# Altium schematic sheet Sch.SchDoc
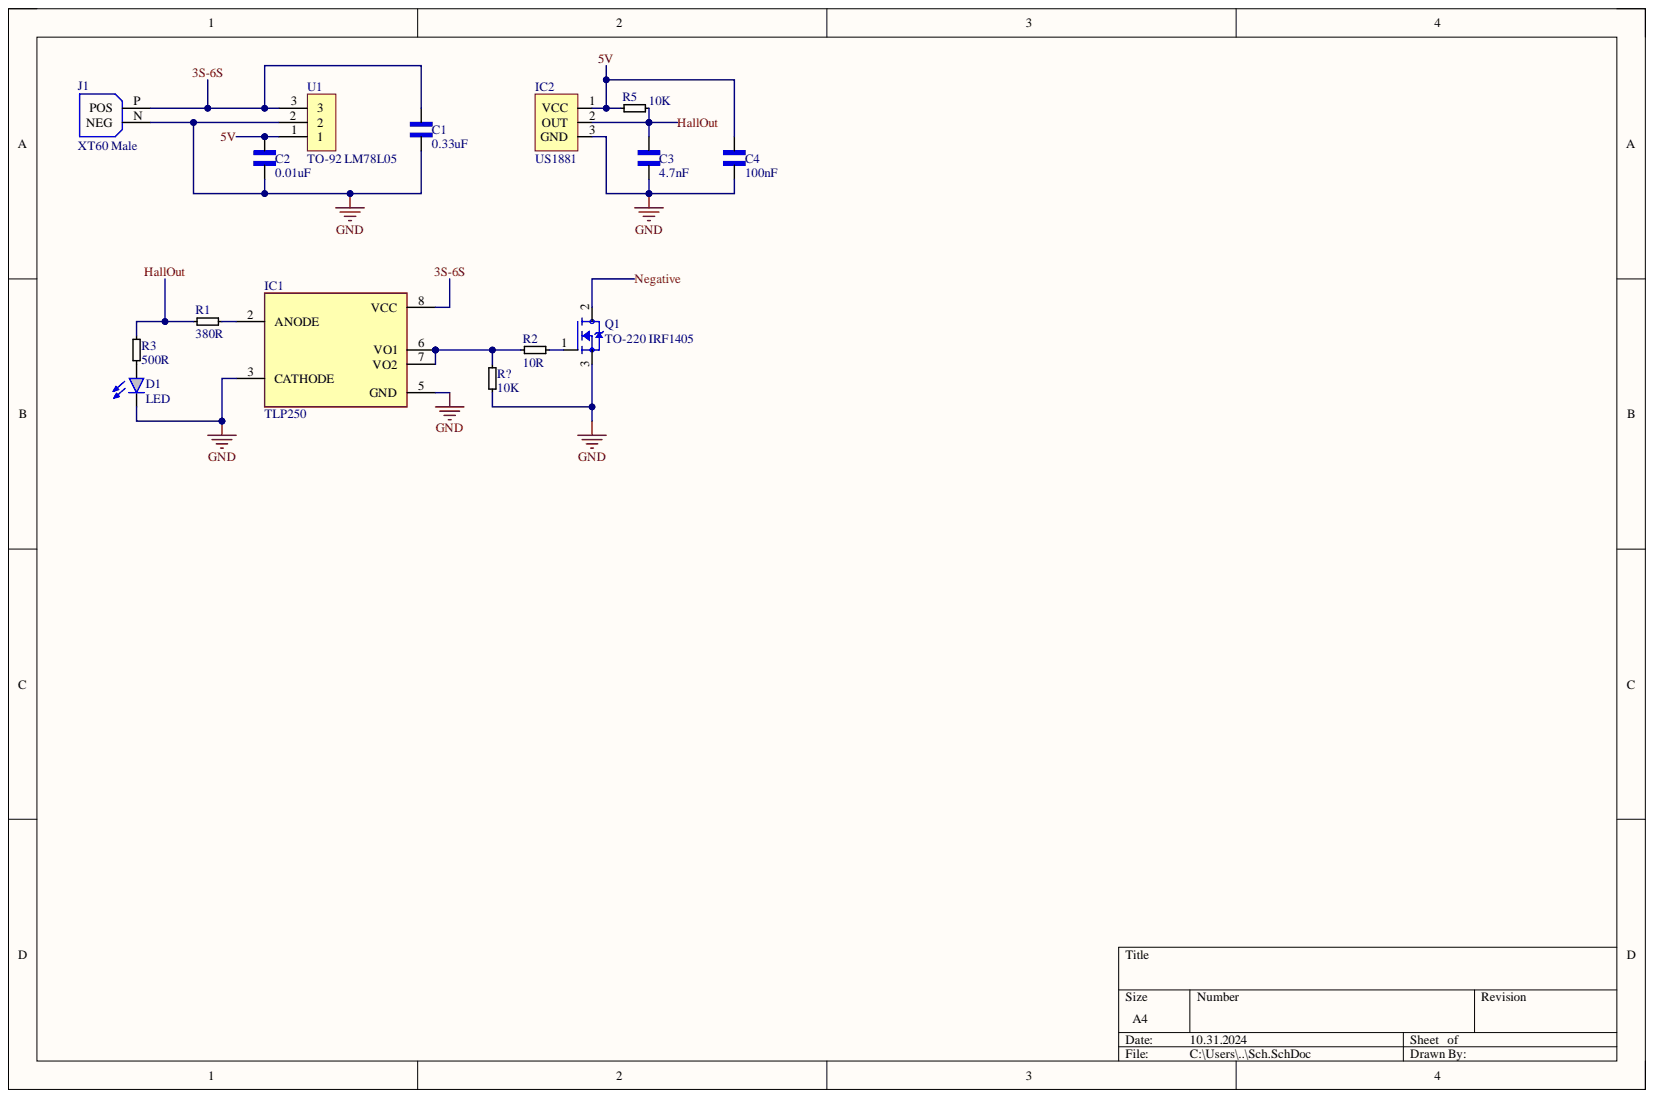
[diagram p0: Sch.SchDoc]

## Parts
C1  0.33uF  [CER-DIP-CAP]
C2  0.01uF  [CER-DIP-CAP]
C3  4.7nF  [CER-DIP-CAP]
C4  100nF  [CER-DIP-CAP]
D1  LED  [LED DIP]
IC1  TLP250  [DIP-8]
IC2  US1881  [UA]
J1  XT60 Male  [XT60 Male Pin]
Q1  TO-220 IRF1405  [TO-220]
R1  380R  [RES DIP]
R2  10R  [RES DIP]
R3  500R  [RES DIP]
R5  10K  [RES DIP]
R?  10K  [RES DIP]
U1  TO-92 LM78L05  [TO-226 TO-92]

## Nets
GND: C1.1 C2.1 C3.1 C4.1 D1.C IC1.3(CATHODE) IC1.5(GND) IC2.3 J1.N Q1.3 R?.1 U1.2
3S-6S: C1.2 IC1.8(VCC) J1.P U1.3
5V: C2.2 C4.2 IC2.1 R5.1 U1.1
HallOut: C3.2 IC2.2 R1.1 R3.1 R5.2
Negative: Q1.2
NetD1_A: D1.A R3.2
NetQ1_1: Q1.1 R2.2
NetR1_2: IC1.2(ANODE) R1.2
NetR2_1: IC1.6(VO1) IC1.7(VO2) R2.1 R?.2

## Library data of the parts
C1-C4 = CAP DIP: Component Kind: Standard; Library Name: MYS-Library.IntLib
D1 = LED DIP: Component Kind: Standard; Library Name: MYS-Library.IntLib
IC1 = TLP250-DIP: Component Kind: Standard; Library Name: MYS-Library.IntLib
J1 = XT60 Male Pin: Component Kind: Standard; Library Name: MYS-Library.IntLib
Q1 = TO-220 MOSFET: Component Kind: Standard; Library Name: MYS-Library.IntLib
R1-R3 R5 R? = RES DIP: Component Kind: Standard; Library Name: MYS-Library.IntLib
U1 = TO-92: Component Kind: Standard; Library Name: MYS-Library.IntLib
IC2 = US1881: Component Kind: Standard; Library Name: MYS-Library.IntLib
